# Altium schematic sheet 07 通用接口.SchDoc("07 通用接口")
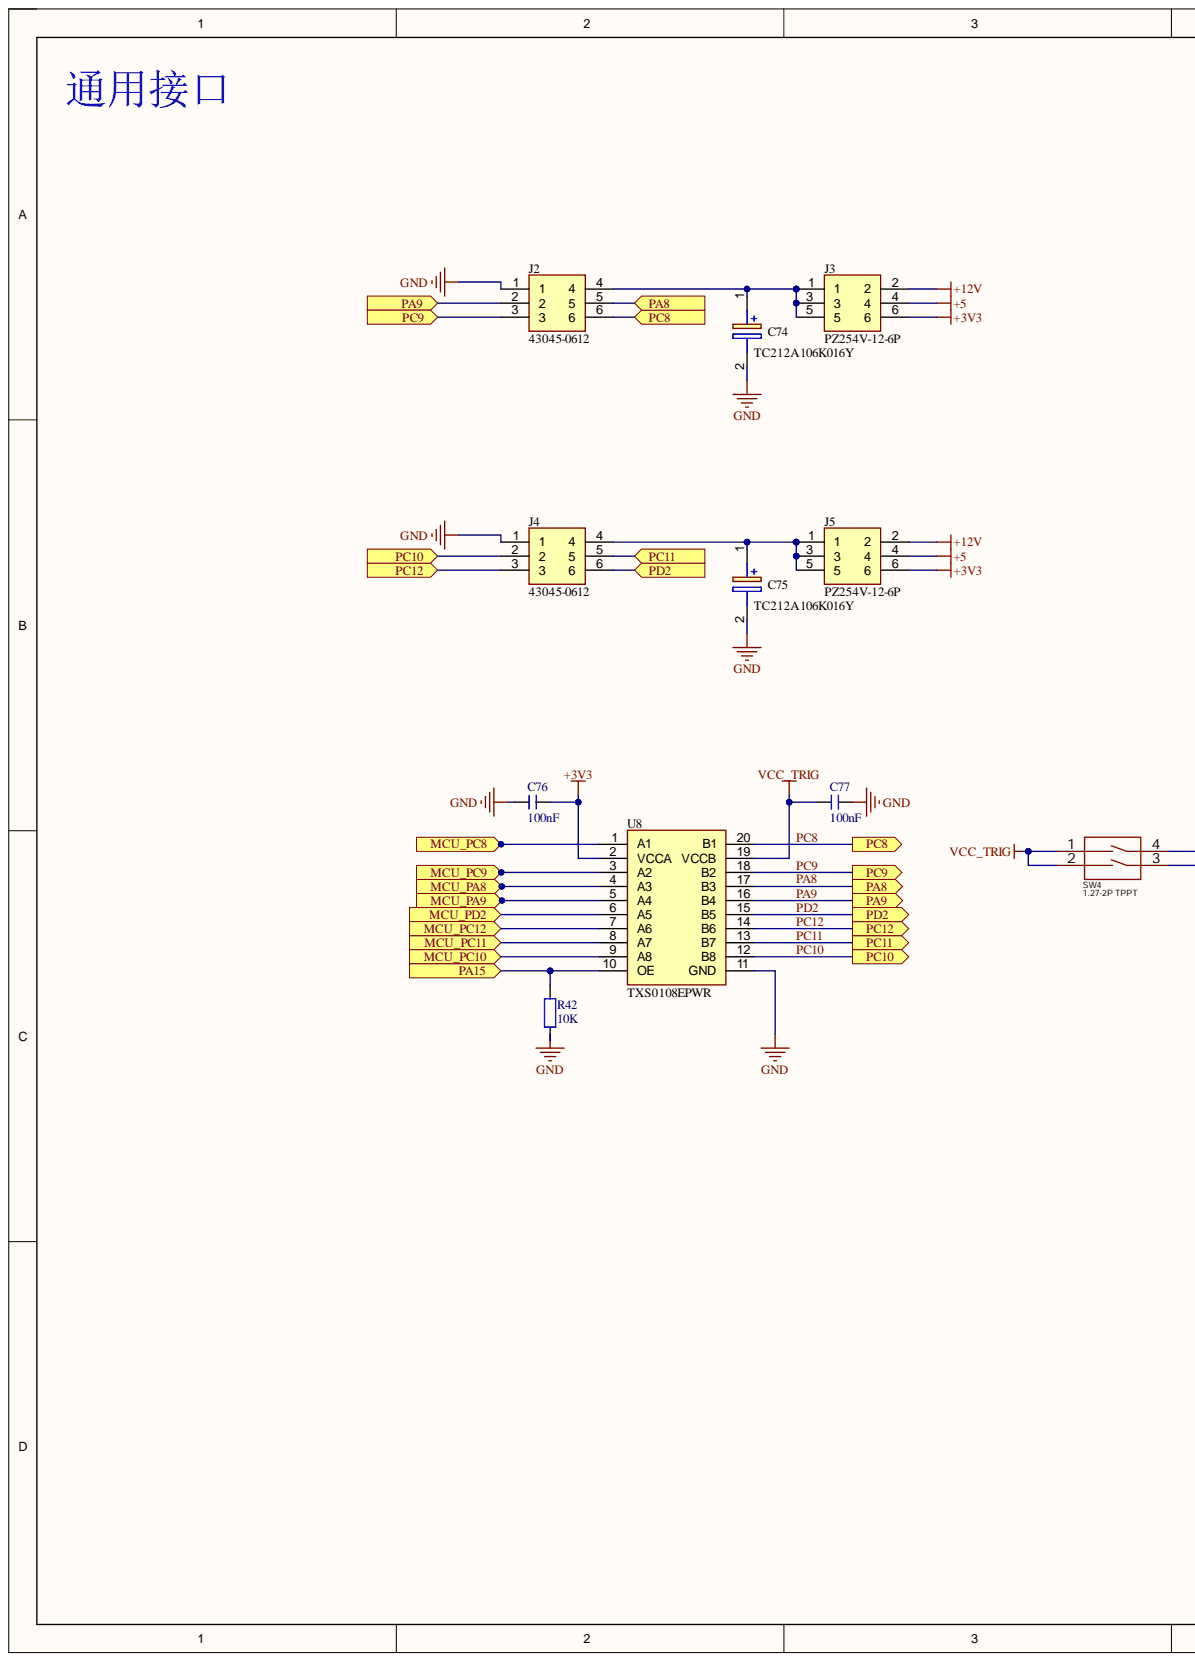
[diagram p0: 07 通用接口.SchDoc, left]
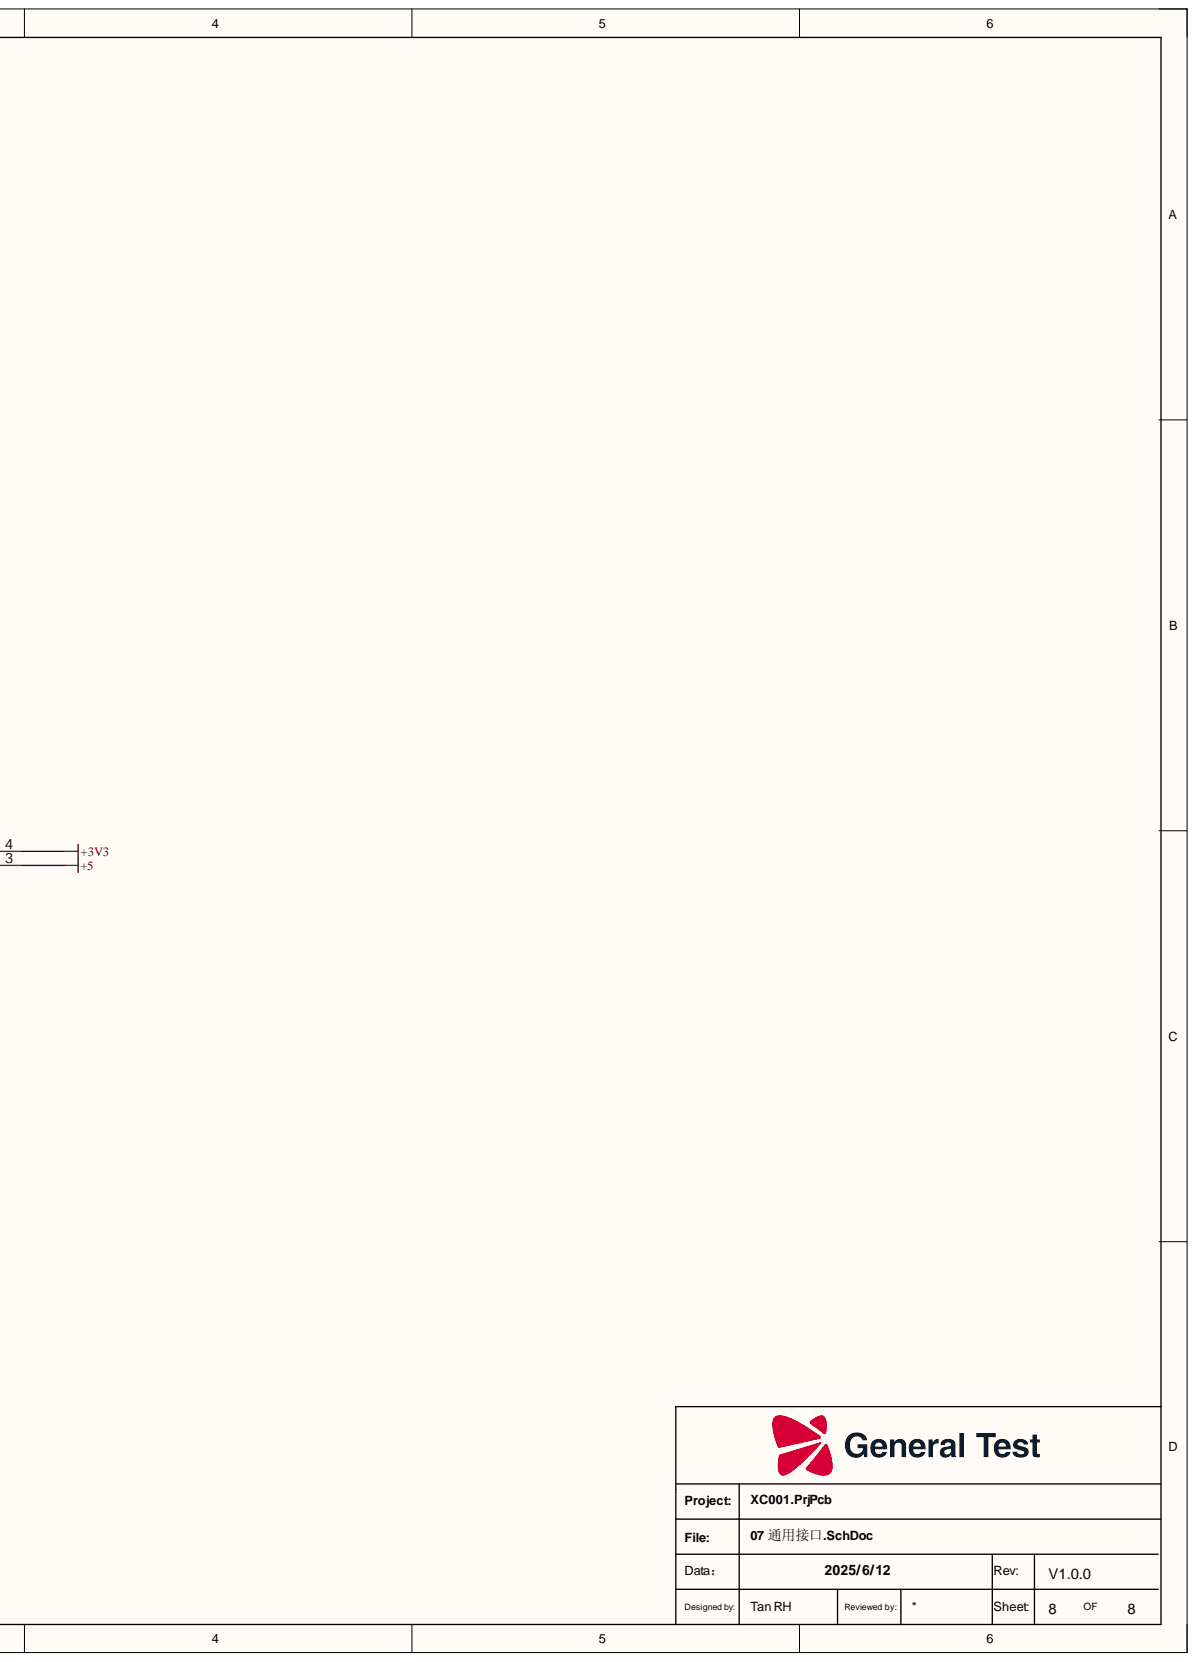
[diagram p1: 07 通用接口.SchDoc, right]

## Parts
C74  TC212A106K016Y  [CAPPM3216X180N]
C75  TC212A106K016Y  [CAPPM3216X180N]
C76  100nF  [C0402_L]
C77  100nF  [C0402_L]
J2  43045-0612  [43045-06YY_121314]
J3  PZ254V-12-6P  [HDRV6W64P254X254_2X3_762X500X890P]
J4  43045-0612  [43045-06YY_121314]
J5  PZ254V-12-6P  [HDRV6W64P254X254_2X3_762X500X890P]
R42  10K  [R0402_L]
SW4  1.27-2P TPPT  [SW-SMD_2P-L5.4-W4.1-P1.27-LS8.4]
U8  TXS0108EPWR  [SOP65P640X120-20N]

## Ports
MCU_PA8, MCU_PA9, MCU_PC8, MCU_PC9, MCU_PC10, MCU_PC11, MCU_PC12, MCU_PD2, PA8, PA9, PA15, PC8, PC9, PC10, PC11, PC12, PD2

## Nets
+3V3: C76.1 J3.6 J5.6 SW4.4 U8.2(VCCA)
+5: J3.4 J5.4 SW4.3
+12V: J3.2 J5.2
GND: C74.2 C75.2 C76.2 C77.1 J2.1 J4.1 R42.1 U8.11(GND)
VCC_TRIG: C77.2 SW4.1 SW4.2 U8.19(VCCB)
MCU_PA8: U8.4(A3) ; port MCU_PA8
MCU_PA9: U8.5(A4) ; port MCU_PA9
MCU_PC8: U8.1(A1) ; port MCU_PC8
MCU_PC9: U8.3(A2) ; port MCU_PC9
MCU_PC10: U8.9(A8) ; port MCU_PC10
MCU_PC11: U8.8(A7) ; port MCU_PC11
MCU_PC12: U8.7(A6) ; port MCU_PC12
MCU_PD2: U8.6(A5) ; port MCU_PD2
PA8: J2.5 U8.17(B3) ; port PA8
PA9: J2.2 U8.16(B4) ; port PA9
PA15: R42.2 U8.10(OE) ; port PA15
PC8: J2.6 U8.20(B1) ; port PC8
PC9: J2.3 U8.18(B2) ; port PC9
PC10: J4.2 U8.12(B8) ; port PC10
PC11: J4.5 U8.13(B7) ; port PC11
PC12: J4.3 U8.14(B6) ; port PC12
PD2: J4.6 U8.15(B5) ; port PD2
NetC74_1: C74.1 J2.4 J3.1 J3.3 J3.5
NetC75_1: C75.1 J4.4 J5.1 J5.3 J5.5

## Library data of the parts
C74 C75 = TC212A106K016Y: Capacitor Polarised; Manufacturer_Name: SUNLORD; Component Kind: Standard; Datasheet Link: https://www.sunlordinc.com//uploads/files/20230823/TC2%20series%20of%20Solid%20Tantalum%20Chip%20Capacitor.pdf; Height: 1.8mm; Manufacturer_Part_Number: TC212A106K016Y
C76 C77 = C: 贴片电容; BOM名称: 无极性贴片电容; 淘宝ID: 封装库来自淘宝 ID：黄晶宝石; Component Kind: Standard; Library Name: 0.1 - 电阻-电容-电感.SchLib; 唯一出售店铺网址: https://shop463601444.taobao.com; 联系售后，技术支持: https://shop463601444.taobao.com
J2 J4 = 43045-0612: Connector; Manufacturer_Name: Molex; Arrow Part Number: 43045-0612; Arrow Price/Stock: https://www.arrow.com/en/products/43045-0612/molex?region=nac; Component Kind: Standard; Datasheet Link: https://www.molex.com/pdm_docs/sd/430450612_sd.pdf; Height: 9.91mm; Manufacturer_Part_Number: 43045-0612; Mouser Part Number: 538-43045-0612; Mouser Price/Stock: https://www.mouser.co.uk/ProductDetail/Molex/43045-0612?qs=KC2ywxza1kqWo9LLJ5Lh3w%3D%3D
J3 J5 = PZ254V-12-6P: Connector; Manufacturer_Name: XFCN; Component Kind: Standard; Datasheet Link: https://datasheet.lcsc.com/lcsc/2003191006_XFCN-PZ254V-12-6P_C492420.pdf; Height: 8.9mm; Library Name: Stm32h743 Pcb.SchLib; Manufacturer_Part_Number: PZ254V-12-6P
R42 = R: 贴片电阻; 封装库来自淘宝卖家 ID：黄晶宝石: 其他店铺购入均为盗版，不享受售后技术支持服务，请立即申请退款; 版权归属: 来自淘宝 ID：黄晶宝石; BOM名称: 贴片电阻; Component Kind: Standard; Library Name: 0.1 - 电阻-电容-电感.SchLib; 联系售后: https://item.taobao.com/item.htm?id=566775463719
SW4 = 1.27-2P TPPT: Manufacturer: SHOU HAN(首韩); Add into BOM: yes; Circuit: 单刀单掷; Current Rating: 25mA; Number of Positions: 2位; Operating Life: 3千次; Voltage Rating: 24V; Color: 黑色; Component Kind: Standard; Convert to PCB: yes; Datasheet: https://atta.szlcsc.com/upload/public/pdf/source/20240424/FE1C45A34229DFDD33BB881170199CBB.pdf; Device: 1.27-2P TPPT; JLCPCB Part Class: Extended Part; LCSC Part Name: 间距1.27mm 2位平推 拨码开关 贴片; Lead Pitch: 1.27mm; Library Name: Stm32h743 Pcb.SchLib; Manufacturer Part: 1.27-2P TPPT; PCB3D: SW-SMD_2P-L5.4-W4.1-P1.27-LS8.4; Supplier: LCSC; Supplier Footprint: SMD; Supplier Part: C7421516; Symbol: 1.27-2P TPPT; Type: 平拨,凹槽式
U8 = TXS0108EPWR: Integrated Circuit; Manufacturer_Name: Texas Instruments; Arrow Part Number: TXS0108EPWR; Arrow Price/Stock: https://www.arrow.com/en/products/txs0108epwr/texas-instruments?utm_currency=USD&region=nac; Component Kind: Standard; Datasheet Link: http://www.ti.com/lit/ds/symlink/txs0108e.pdf; Height: 1.2mm; Library Name: Stm32h743 Pcb.SchLib; Manufacturer_Part_Number: TXS0108EPWR; Mouser Part Number: 595-TXS0108EPWR; Mouser Price/Stock: https://www.mouser.co.uk/ProductDetail/Texas-Instruments/TXS0108EPWR?qs=IUbkBnfnSQLgiWtCbWtYOw%3D%3D
